# Altium schematic sheet Peripherals.SchDoc
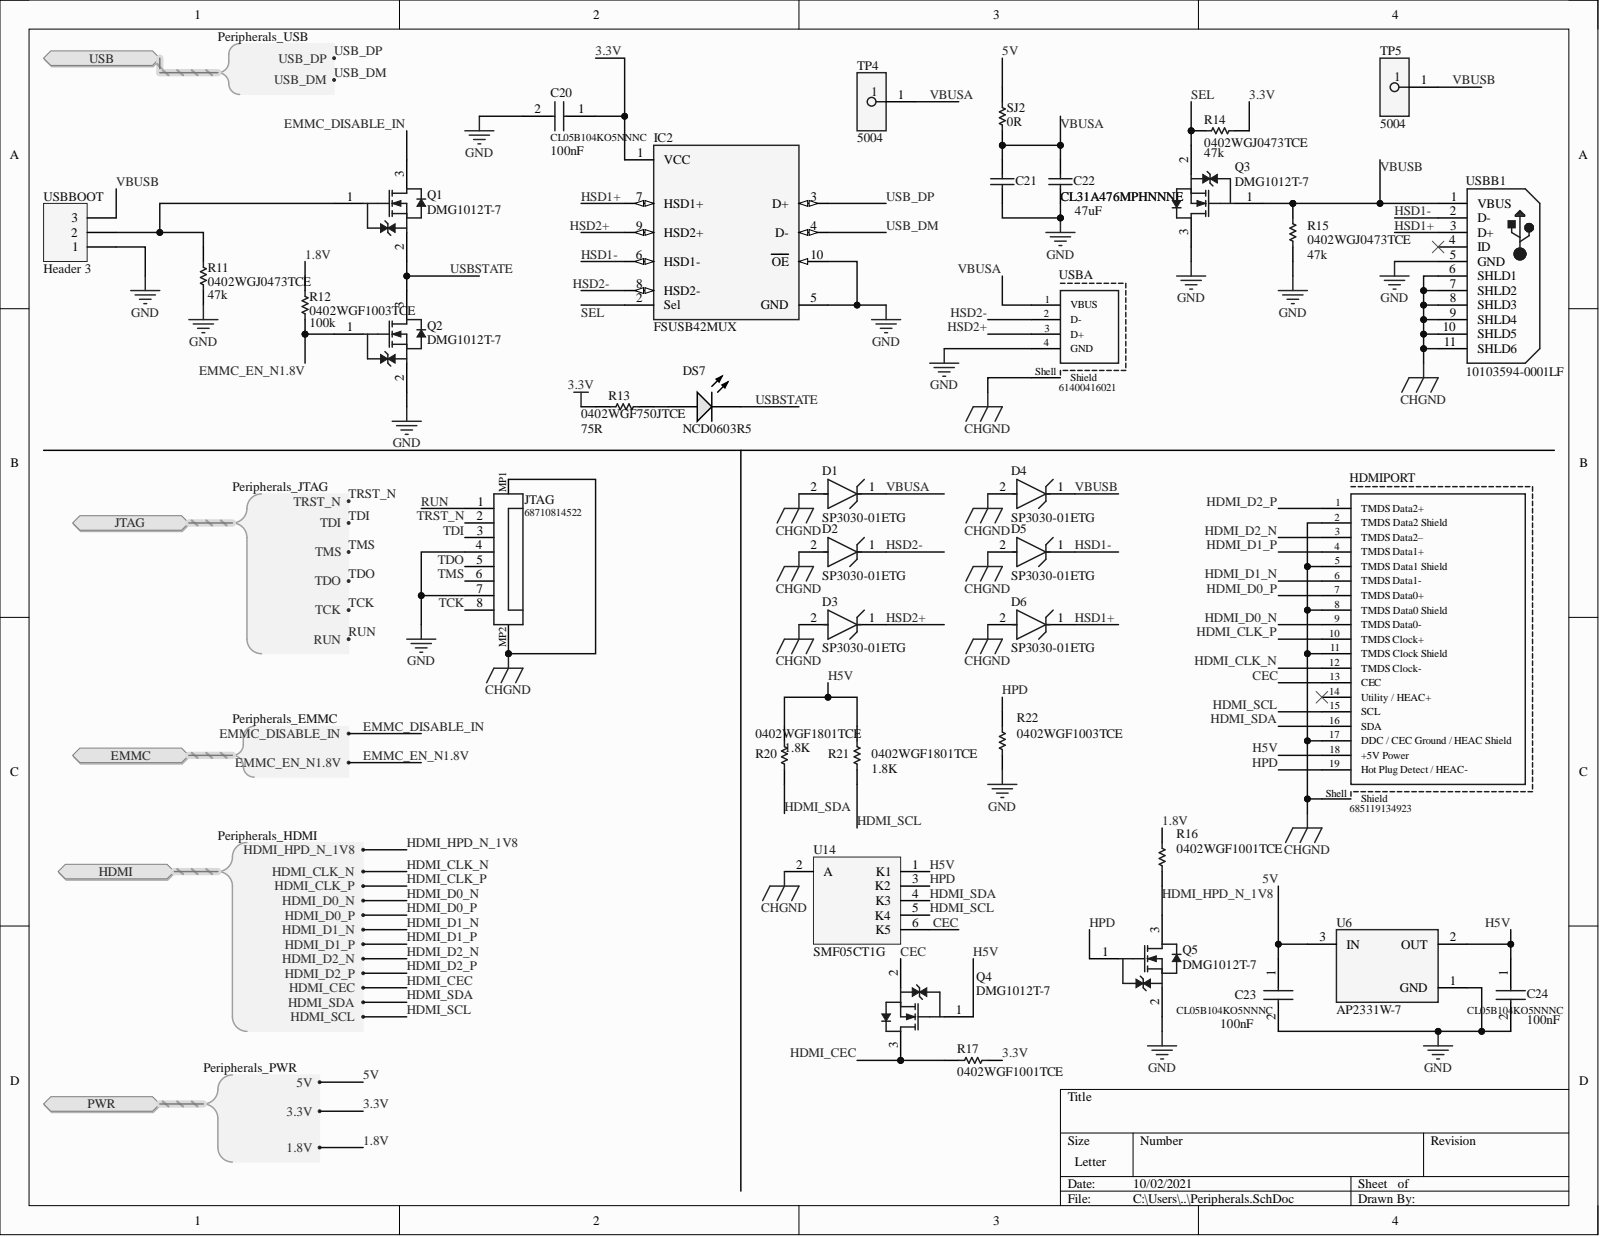
[diagram p0: Peripherals.SchDoc]

## Parts
C20  CL05B104KO5NNNC  value 100nF  [CAPC1005X55N]
C21  CL31A476MPHNNNE  [C1206]
C22  CL31A476MPHNNNE  value 47uF  [C1206]
C23  CL05B104KO5NNNC  value 100nF  [CAPC1005X55N]
C24  CL05B104KO5NNNC  value 100nF  [CAPC1005X55N]
D1  SP3030-01ETG  [FP-SP3030-MFG]
D2  SP3030-01ETG  [FP-SP3030-MFG]
D3  SP3030-01ETG  [FP-SP3030-MFG]
D4  SP3030-01ETG  [FP-SP3030-MFG]
D5  SP3030-01ETG  [FP-SP3030-MFG]
D6  SP3030-01ETG  [FP-SP3030-MFG]
DS7  NCD0603R5  [AVAG-HSMX-C190_V]
HDMIPORT  685119134923  [685119134923]
IC2  FSUSB42MUX  [FP-846AP-MFG]
JTAG  68710814522  [68710814522]
Q1  DMG1012T-7  [DIOD-SOT523-3_V]
Q2  DMG1012T-7  [DIOD-SOT523-3_V]
Q3  DMG1012T-7  [DIOD-SOT523-3_V]
Q4  DMG1012T-7  [DIOD-SOT523-3_V]
Q5  DMG1012T-7  [DIOD-SOT523-3_V]
R11  0402WGJ0473TCE  [0402-A]
R12  0402WGF1003TCE  [0402]
R13  0402WGF750JTCE  spec: 75R  [RESC1005X40X25ML05T10]
R14  0402WGJ0473TCE  value 47k  [0402-A]
R15  0402WGJ0473TCE  value 47k  [0402-A]
R16  0402WGF1001TCE  [0402]
R17  0402WGF1001TCE  [0402]
R20  0402WGF1801TCE  value 1.8K  [0402]
R21  0402WGF1801TCE  value 1.8K  [0402]
R22  0402WGF1003TCE  [0402]
SJ2  0R  [J1-0603]
TP4  5004  [FP-5004-MFG]
TP5  5004  [FP-5004-MFG]
U6  AP2331W-7  [FP-SC59-IPC_B]
U14  SMF05CT1G  [ONSC-SC70-6-419B-02_W_V]
USBA  61400416021  [61400416021]
USBB1  10103594-0001LF  [FCI-10103594-0001LF_V]
USBBOOT  Header 3  [HDR1X3]

## Ports
EMMC, EMMC.EMMC_DISABLE_IN, EMMC.EMMC_EN_N1.8V, HDMI, HDMI.HDMI_CEC, HDMI.HDMI_CLK_N, HDMI.HDMI_CLK_P, HDMI.HDMI_D0_N, HDMI.HDMI_D0_P, HDMI.HDMI_D1_N, HDMI.HDMI_D1_P, HDMI.HDMI_D2_N, HDMI.HDMI_D2_P, HDMI.HDMI_HPD_N_1V8, HDMI.HDMI_SCL, HDMI.HDMI_SDA, JTAG, JTAG.RUN, JTAG.TCK, JTAG.TDI, JTAG.TDO, JTAG.TMS, JTAG.TRST_N, PWR, PWR.1.8V, PWR.3.3V, PWR.5V, USB, USB.USB_DM, USB.USB_DP

## Nets
CHGND: D1.2 D2.2 D3.2 D4.2 D5.2 D6.2 HDMIPORT.2(TMDS_Data2_Shield) HDMIPORT.5(TMDS_Data1_Shield) HDMIPORT.8(TMDS_Data0_Shield) HDMIPORT.11(TMDS_Clock_Shield) HDMIPORT.17(DDC_/_CEC_Ground_/_HEAC_Shield) HDMIPORT.Shell(Shield) JTAG.MP1 JTAG.MP2 U14.2(A) USBA.Shell(Shield) USBB1.6(SHLD1) USBB1.7(SHLD2) USBB1.8(SHLD3) USBB1.9(SHLD4) USBB1.10(SHLD5) USBB1.11(SHLD6)
GND: C20.2 C21.1 C22.1 C23.2 C24.2 IC2.5(GND) IC2.10(OE) JTAG.4 JTAG.7 Q2.2 Q3.3 Q5.2 R11.1 R15.1 R22.2 U6.1 USBA.4(GND) USBB1.5(GND) USBBOOT.1
1.8V: R12.2 R16.1 ; port PWR
3.3V: C20.1 IC2.1(VCC) R13.2 R14.1 R17.2 ; port PWR
5V: C23.1 SJ2.2 U6.3 ; port PWR
CEC: HDMIPORT.13(CEC) Q4.2 U14.6(K5)
EMMC_DISABLE_IN: Q1.3 ; port EMMC
EMMC_EN_N1.8V: Q2.1 R12.1 ; port EMMC
H5V: C24.1 HDMIPORT.18(+5V_Power) Q4.1 R20.2 R21.2 U6.2 U14.1(K1)
HDMI_CEC: Q4.3 R17.1 ; port HDMI
HDMI_CLK_N: HDMIPORT.12(TMDS_Clock-) ; port HDMI
HDMI_CLK_P: HDMIPORT.10(TMDS_Clock+) ; port HDMI
HDMI_D0_N: HDMIPORT.9(TMDS_Data0-) ; port HDMI
HDMI_D0_P: HDMIPORT.7(TMDS_Data0+) ; port HDMI
HDMI_D1_N: HDMIPORT.6(TMDS_Data1-) ; port HDMI
HDMI_D1_P: HDMIPORT.4(TMDS_Data1+) ; port HDMI
HDMI_D2_N: HDMIPORT.3(TMDS_Data2–) ; port HDMI
HDMI_D2_P: HDMIPORT.1(TMDS_Data2+) ; port HDMI
HDMI_HPD_N_1V8: Q5.3 R16.2 ; port HDMI
HDMI_SCL: HDMIPORT.15(SCL) R21.1 U14.5(K4) ; port HDMI
HDMI_SDA: HDMIPORT.16(SDA) R20.1 U14.4(K3) ; port HDMI
HPD: HDMIPORT.19(Hot_Plug_Detect_/_HEAC-) Q5.1 R22.1 U14.3(K2)
HSD1+: D6.1 IC2.7(HSD1+) USBB1.3(D+)
HSD1-: D5.1 IC2.6(HSD1-) USBB1.2(D-)
HSD2+: D3.1 IC2.9(HSD2+) USBA.3(D+)
HSD2-: D2.1 IC2.8(HSD2-) USBA.2(D-)
RUN: JTAG.1 ; port JTAG
SEL: IC2.2(Sel) Q3.2 R14.2
TCK: JTAG.8 ; port JTAG
TDI: JTAG.3 ; port JTAG
TDO: JTAG.5 ; port JTAG
TMS: JTAG.6 ; port JTAG
TRST_N: JTAG.2 ; port JTAG
USB_DM: IC2.4(D-) ; port USB
USB_DP: IC2.3(D+) ; port USB
USBSTATE: DS7.2 Q1.2 Q2.3
VBUSA: C21.2 C22.2 D1.1 SJ2.1 TP4.1 USBA.1(VBUS)
VBUSB: D4.1 Q3.1 R15.2 TP5.1 USBB1.1(VBUS) USBBOOT.3
NetDS7_1: DS7.1 R13.1
NetQ1_1: Q1.1 R11.2 USBBOOT.2

Not connected: HDMIPORT 14(Utility_/_HEAC+); USBB1 4(ID)

## Library data of the parts
C20 C23 C24 = C0402C560K5RACTU: 100nF 0402; Manufacturer_Name: Kemet; Arrow Part Number: C0402C560K5RACTU; Component Kind: Standard; Datasheet Link: https://content.kemet.com/datasheets/KEM_C1002_X7R_SMD.pdf; Height: 0.55mm; Manufacturer_Part_Number: C0402C560K5RACTU; Mouser Part Number: 80-C0402C560K5RACTU; Mouser Price/Stock: https://www.mouser.co.uk/ProductDetail/KEMET/C0402C560K5RACTU?qs=gt1LBUVyoHmQZW5v3ySgNQ%3D%3D
C21 C22 = Cap: 47uF 1206; Component Kind: Standard; LatestRevisionDate: 17-Jul-2002; LatestRevisionNote: Re-released for DXP Platform.; LCSC Part #: C96123; Library Name: Miscellaneous Devices.IntLib; PackageDescription: Radial Cap, Thru-Hole; 2 Leads; 0.3 in Pin Spacing; PackageReference: RAD-0.3; Published: 8-Jun-2000; Signal Integrity: Cap; Simulation: CAP; Publisher: Altium Limited
  C21: Value: 47uF
D1-D6 = CMP-08607-000070-1: TVS DIODE 5V 10V SOD882; Manufacturer: Littelfuse; Automotive Grade: Grade 1; Capacitance: 0.5pF; Direction: Unidirectional; Max Junction Temp: +150°C; Number Of Channels: 1; Peak Pulse Current: 3A; Value: 0.5pF; Automotive: Yes; Category: Diode; Clamping Voltage: 10V; Component Kind: Standard; ComponentLink2Description: Datasheet; ComponentLink2URL: https://www.littelfuse.com/~/media/electronics/datasheets/tvs_diode_arrays/littelfuse_tvs_diode_array_sp3030_datasheet.pdf.pdf; Device Class L1: Circuit Protection; Device Class L2: TVS Diodes; Device Class L3: unset; Digikey Description: TVS DIODE 5V 10V SOD882; Height: 0.6mm; Lead Free: Yes; Library Name: Altium Content Vault; Manufacturer Part Number: SP3030-01ETG; Mouser Description: TVS Diodes / ESD Suppressors 5V 1uA 22kV UNIDIRECTIONAL; Octopart: https://octopart.com/sp3030-01etg-littelfuse-29687287; Package: SP3030; Reverse Standoff Voltage: 5V; RoHS: TRUE; Temperature: -40°C to +125°C; Temperature Range High: +125°C; Temperature Range Low: -40°C
DS7 = CMP-1744-00003-1: 0603 LIGHT EMITTING DIODES (LED) ROHS; Case\Package: 0603; Power Rating: 100 mW; Resistance: 390 Ohm; Tolerance: 1%; Voltage Rating: 50 V; Case Code (Imperial): 0603; Case Code (Metric): 1608; Component Kind: Standard; ComponentLink1Description: Manufacturer URL; ComponentLink1URL: http://www.avagotech.com/; ComponentLink2Description: Datasheet; ComponentLink2URL: http://docs.avagotech.com/docs/AV02-0551EN; ComponentLink3Description: Package Specification; ComponentLink3URL: http://www.fairchildsemi.com/dwg/MA/MA03D.pdf; DatasheetVersion: Mar-12; Height: 0.45 mm; Length: 1.6 mm; Library Name: Altium Content Vault; Max Operating Temperature: 155 degC; Min Operating Temperature: -55 degC; Mount: Surface Mount; Package Quantity: 5000; PackageDescription: 2-Pin Surface Mount Device, Body 1.6 x 0.8 mm, Height 0.9 mm; PackageReference: HSMX-C190; Packaging: Tape and Reel; Pins: 2; REACH SVHC: No SVHC; RoHSCompliant: true; Voltage Rating (DC): 50 V; Width: 0.8 mm
HDMIPORT = CMP-1502-00169-1: HDMI Type A Receptacle WR-HDMI, Horizontal, SMT; Manufacturer: Wurth Elektronik; Contact Resistance: 30mOhm; Rated Current: 0.5A; Working Voltage: 40VDC; Component Kind: Standard; Connector Type: HDMITypeA; Datasheet URL: https://katalog.we-online.de/em/datasheet/685119134923.pdf; Gender: Receptacle; Library Name: Altium Content Vault; Manufacturer URL: http://www.we-online.de; Mounting Technology: SMT; Operating Temperature: -20to85degC; Orientation: Horizontal; Package Reference: 685119134923; Packaging: TapeandReel; Part Number: 685119134923; Publisher: WurthElektronik
IC2 = CMP-07103-000004-1: IC USB SWITCH DPDT 10MSOP; Current: 100mA; Data Rate: 480Mbps; Max Supply Voltage: 4.3V; Min Supply Voltage: 3V; Number of Channels: 2; Number of Outputs: 4; On-State Resistance: 6.5R; Supply Type: Single; Case/Package: MSOP; Component Kind: Standard; Height: 0.85mm; LCSC Part #: C11355; Lead Free: Lead Free; Length: 3mm; Library Name: Altium Content Vault; Max Operating Temperature: 85°C; Min Operating Temperature: -40°C; Mount: Surface Mount; Number of Inputs: 2; Number of Pins: 10; Operating Supply Voltage: 4.3V; Package Quantity: 1; Packaging: Tape and Reel; Radiation Hardening: No; REACH SVHC: No SVHC; Throw Configuration: DPDT; Voltage: 4.3V; Weight: 0.1125g; Width: 3mm
JTAG = CMP-1502-01528-1: SMT ZIF Connectors WR-FPC, Horizontal, Bottom Contact, pitch 0.5 mm, 8 pins; Manufacturer: Wurth Elektronik; Contact Resistance: 20mOhm; Pin Number: 8; Pitch: 0.5mm; Rated Current: 0.5A; Withstanding Voltage: 250VAC; Working Voltage: 50VAC; Component Kind: Standard; Contact Design: BottomContact; Datasheet URL: https://katalog.we-online.de/em/datasheet/68710814522.pdf; Library Name: Altium Content Vault; Manufacturer URL: http://www.we-online.de; Mounting Technology: SMT; Operating Temperature: -25to85degC; Orientation: Horizontal; Package Reference: 68710814522; Packaging: TapeandReel; Part Number: 68710814522; Publisher: WurthElektronik
Q1-Q5 = CMP-2000-07450-1: N-Channel Enhancement Mode MOSFET, 20 V, 630 mA, -55 to 150 degC, 3-Pin SOT23, RoHS, Tape and Reel; Manufacturer: Diodes Inc; Case\/Package: SOT-523; Continuous Drain Current (ID): 630mA; Drain to Source Breakdown Voltage: 20V; Drain to Source Resistance: 300mOhm; Element Configuration: Single; Fall Time: 12.3ns; Gate to Source Voltage (Vgs): 6V; Input Capacitance: 60.67pF; Max Power Dissipation: 280mW; Number of Channels: 1; On-State Resistance: 400MOhm; Rise Time: 7.4ns; Threshold Voltage: 1V; Turn-Off Delay Time: 26.7ns; Turn-On Delay Time: 5.1ns; Component Kind: Standard; Contact Plating: Matte; Datasheet URL: https://www.diodes.com/assets/Datasheets/ds31783.pdf; Datasheet Version: Rev. 3-2, 01/2012; Drain to Source Voltage (Vdss): 20V; Height: 0.8mm; LCSC Part #: C20512; Lead Free: LeadFree; Length: 1.7mm; Library Name: Altium Content Vault; Manufacturer URL: http://www.diodes.com/; Max Operating Temperature: 150degC; Min Operating Temperature: -55degC; Mount: SurfaceMount; Mounting Technology: SurfaceMount; Number of Elements: 1; Number of Pins: 3; Package Description: 3-Pin Small Outline Transistor, Body 1.6 x 0.8 mm, Pitch 0.5 mm; Package Quantity: 3000; Package Reference: SOT523-3; Packaging: TapeandReel; Power Dissipation: 280mW; Radiation Hardening: No; Rds On Max: 400mOhm; REACH SVHC: NoSVHC; RoHSCompliant: true; Weight: 7.1E-05oz; Width: 0.85mm
R11 R14 R15 = Res1: 47k 0402; Component Kind: Standard; LatestRevisionDate: 17-Jul-2002; LatestRevisionNote: Re-released for DXP Platform.; LCSC Part #: C25792; Library Name: Miscellaneous Devices.IntLib; PackageDescription: Axial Device, Thru-Hole; 2 Leads; 0.3 in Pin Spacing; PackageReference: AXIAL-0.3; Published: 8-Jun-2000; Signal Integrity: Res; Simulation: RESISTOR; Publisher: Altium Limited
  R11: Value: 47K
  R14: Value: 47k
R12 R22 = Res1: 100k 0402; Component Kind: Standard; LatestRevisionDate: 17-Jul-2002; LatestRevisionNote: Re-released for DXP Platform.; Library Name: Miscellaneous Devices.IntLib; PackageDescription: Axial Device, Thru-Hole; 2 Leads; 0.3 in Pin Spacing; PackageReference: AXIAL-0.3; Published: 8-Jun-2000; Signal Integrity: Res; Simulation: RESISTOR; Publisher: Altium Limited
R13 = CMP-2002-07344-1: 75R 0402; Manufacturer: Yageo / Phycomp; Part Number: RC0402FR-07100RL; Component Kind: Standard; Library Name: Altium Content Vault
R16 R17 = Res1: 1k 0402; Component Kind: Standard; LatestRevisionDate: 17-Jul-2002; LatestRevisionNote: Re-released for DXP Platform.; Library Name: Miscellaneous Devices.IntLib; PackageDescription: Axial Device, Thru-Hole; 2 Leads; 0.3 in Pin Spacing; PackageReference: AXIAL-0.3; Published: 8-Jun-2000; Signal Integrity: Res; Simulation: RESISTOR; Publisher: Altium Limited
R20 R21 = Res1: 1.8k 0402; Component Kind: Standard; LatestRevisionDate: 17-Jul-2002; LatestRevisionNote: Re-released for DXP Platform.; Library Name: Miscellaneous Devices.IntLib; PackageDescription: Axial Device, Thru-Hole; 2 Leads; 0.3 in Pin Spacing; PackageReference: AXIAL-0.3; Published: 8-Jun-2000; Signal Integrity: Res; Simulation: RESISTOR; Publisher: Altium Limited
SJ2 = Res1: Resistor; Component Kind: Standard; LatestRevisionDate: 17-Jul-2002; LatestRevisionNote: Re-released for DXP Platform.; LCSC Part #: C21189; Library Name: Miscellaneous Devices.IntLib; PackageDescription: Axial Device, Thru-Hole; 2 Leads; 0.3 in Pin Spacing; PackageReference: AXIAL-0.3; Published: 8-Jun-2000; Signal Integrity: Res; Simulation: RESISTOR; Value: 0R; Publisher: Altium Limited
TP4 TP5 = CMP-2000-05575-2: PC TEST POINT MINIATURE YELLOW; Manufacturer: Keystone; Is Female: yes; Number Of Contacts: 1; Automotive: No; Category: Conn; Component Kind: Standard; ComponentLink2Description: Datasheet; ComponentLink2URL: https://www.keyelco.com/product-pdf.cfm?p=1313; Contact Material: Silver; Device Class L1: Connectors; Device Class L2: unset; Device Class L3: unset; Digikey Description: PC TEST POINT MINIATURE YELLOW; Height: 4.72mm; Lead Free: Yes; Library Name: Altium Content Vault; Manufacturer Part Number: 5004; Mouser Description: TEST POINT PC MINI .040"D YELLOW; Octopart: https://octopart.com/5004-keystone-28591; Package: 5004; RoHS: TRUE
U6 = CMP-12752-000002-1: LOAD SWITCH SC59; Current: 200mA; Fault Protection: Over Temperature; Interface: On/Off; Max Supply Voltage: 5.2V; Min Supply Voltage: 2.7V; Number of Outputs: 1; On-State Resistance: 350mR; Output Configuration: High Side; Turn-On Delay Time: 700us; Case/Package: TO-236-3; Component Kind: Standard; Height: 1.3mm; Length: 3.1mm; Library Name: Altium Content Vault; Max Operating Temperature: 85°C; Max Output Current: 200mA; Min Operating Temperature: -40°C; Mount: Surface Mount; Number of Pins: 59; Output Current: 200mA; Package Quantity: 3000; Packaging: Tape and Reel; Radiation Hardening: No; REACH SVHC: No SVHC; Voltage: 5.2V; Width: 1.7mm
U14 = CMP-1061-00155-1: 5-Line Transient Voltage Suppressor Array, 6-Pin SC70, Pb-Free, Tape and Reel; Manufacturer: On Semiconductor; Direction: Unidirectional; IR Max (uA): 5; PPK Max (W): 100; Component Kind: Standard; ComponentLink1Description: Manufacturer URL; ComponentLink1URL: http://www.onsemi.com/; ComponentLink2Description: Datasheet; ComponentLink2URL: http://www.onsemi.com/pub_link/Collateral/SMF05C-D.PDF; ComponentLink3Description: Package Specification; ComponentLink3URL: http://www.onsemi.com/pub_link/Collateral/SMF05C-D.PDF; DatasheetVersion: Rev. 4; Library Name: Altium Content Vault; Mounting Technology: Surface Mount; PackageDescription: 6-Pin Small Outline Transistor, Body 2 x 1.25 mm, Pitch 0.65 mm; PackageReference: SC70-6-419B-02_W; PackageVersion: Rev. W; Packing: Tape and Reel; PartNumber: SMF05CT1G; RoHS: Pb-Free
USBA = CMP-1502-03227-1: USB 2.0 Type A Receptacle WR-COM, Horizontal, THT; Manufacturer: Wurth Elektronik; Contact Resistance: 20mOhm; Rated Current: 1.5A; Working Voltage: 30VAC; Component Kind: Standard; Connector Type: USB2.0TypeA; Datasheet URL: https://katalog.we-online.de/em/datasheet/61400416021.pdf; Gender: Receptacle; Library Name: Altium Content Vault; Manufacturer URL: http://www.we-online.de; Mounting Technology: THT; Operating Temperature: -40to105degC; Orientation: Horizontal; Package Reference: 61400416021; Packaging: Tray; Part Number: 61400416021; Publisher: WurthElektronik
USBB1 = CMP-1698-00007-1: Micro USB 2.0 B Receptacle, -55 to 85 degC, 5-Pin SMD, RoHS, Tape and Reel; Case\Package: 0603; Power Rating: 100 mW; Resistance: 4.7 kohm; Tolerance: 1%; Type (Resistor): Thick Film; Voltage Rating: 75 V; Case Code (Imperial): 0603; Case Code (Metric): 1608; Component Kind: Standard; ComponentLink1Description: Manufacturer URL; ComponentLink1URL: http://portal.fciconnect.com/; ComponentLink2Description: Datasheet; ComponentLink2URL: http://portal.fciconnect.com/Comergent//fci/drawing/10103594.pdf; DatasheetVersion: Rev. A, 06/2010; Height: 0.45 mm; Length: 1.55 mm; Library Name: Altium Content Vault; Max Operating Temperature: 155 degC; Min Operating Temperature: -55 degC; Mount: Surface Mount; Package Quantity: 5000; PackageDescription: 5-Pin Surface Mount Device, Body 8.04 x 5.62 mm; PackageReference: FCI-10103594-0001LF; Packaging: Tape and Reel; Pins: 2; REACH SVHC: Unknown; RoHSCompliant: true; Weight: 7.1E-05 oz; Width: 0.85 mm
USBBOOT = Header 3: Header, 3-Pin; Component Kind: Standard; LatestRevisionDate: 17-Jul-2002; LatestRevisionNote: Re-released for DXP Platform.; Library Name: Miscellaneous Connectors.IntLib; Signal Integrity: Connector; Publisher: Altium Limited
